# Altium schematic sheet [03] - External Connectors.SchDoc("[03] - External Connectors")
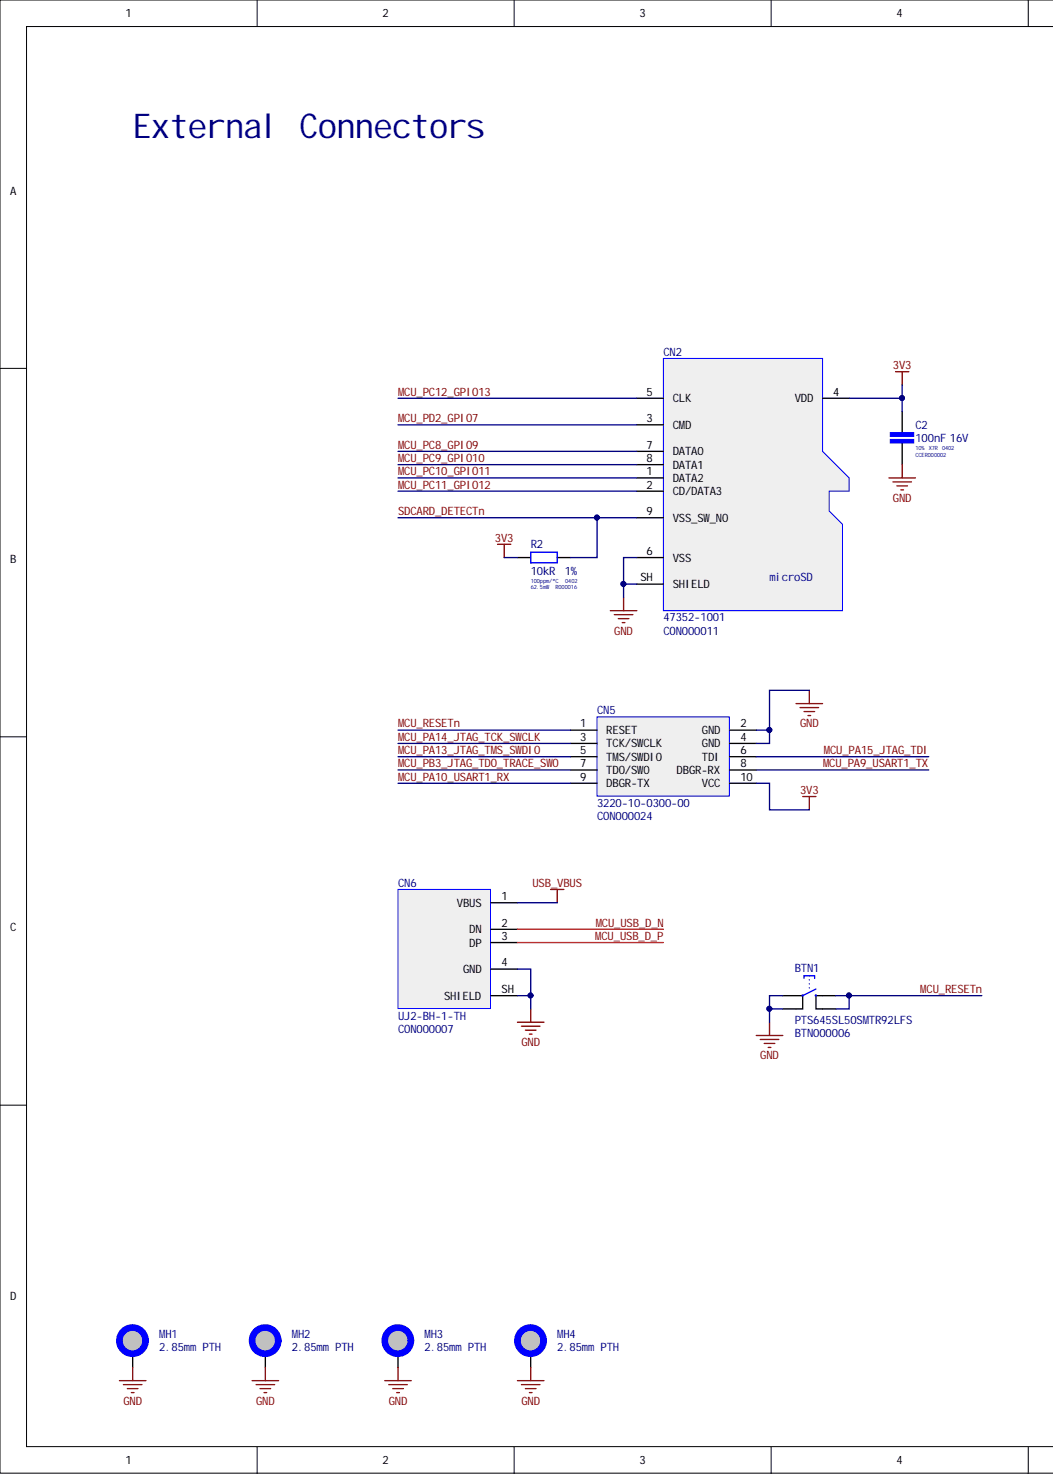
[diagram p0: [03] - External Connectors.SchDoc, left]
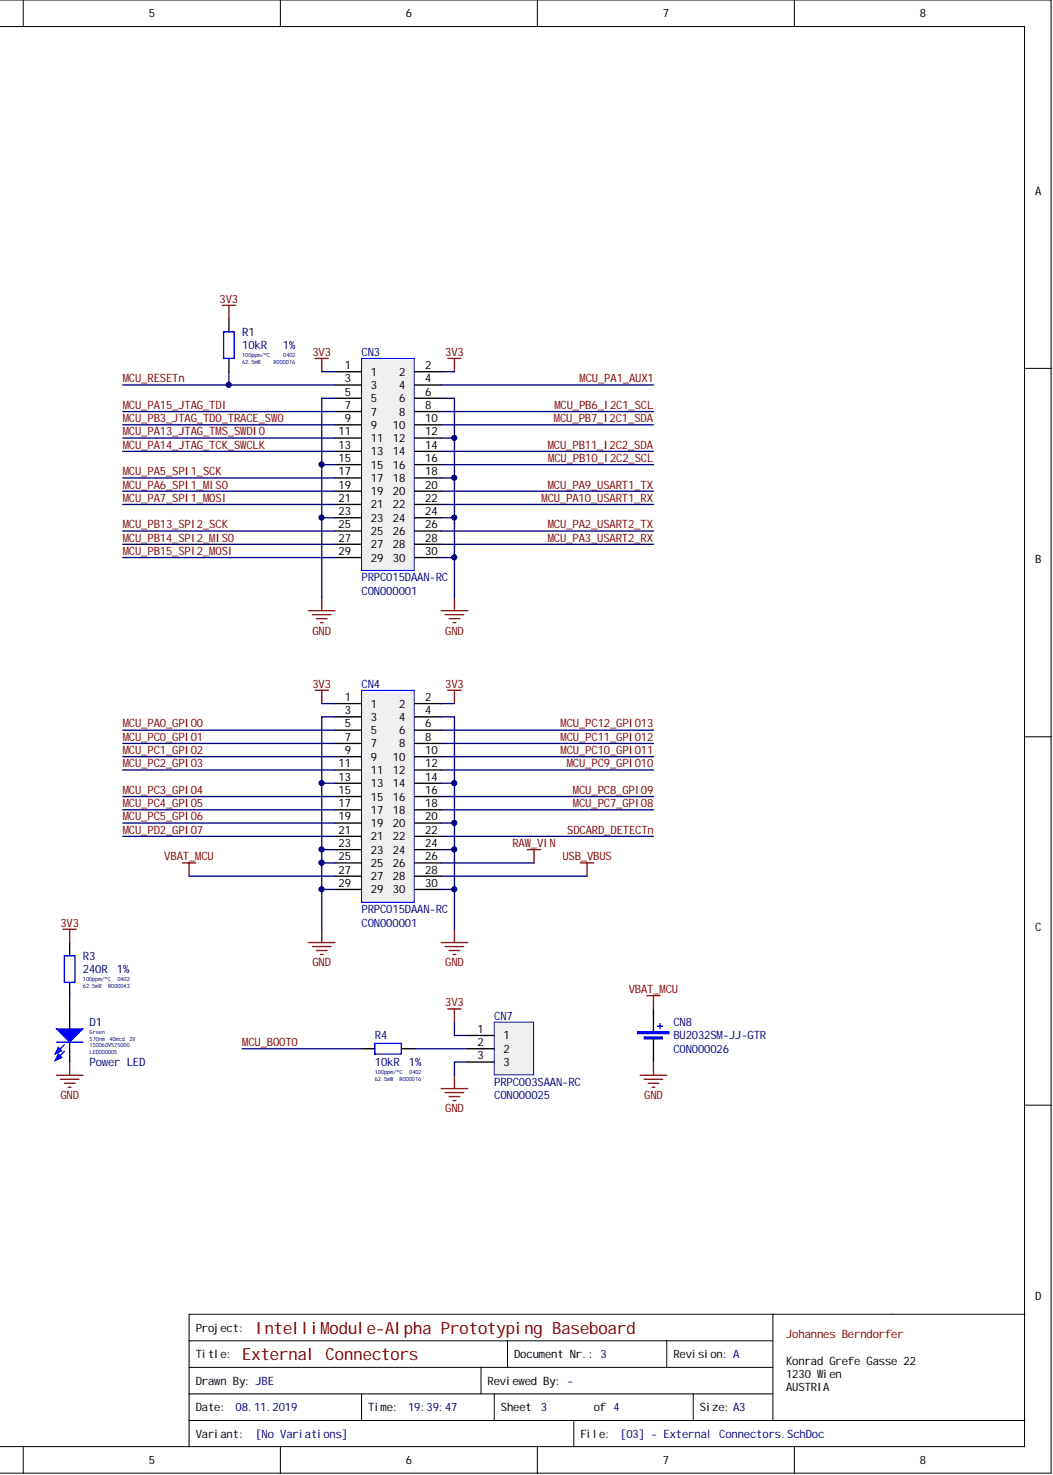
[diagram p1: [03] - External Connectors.SchDoc, right]

## Parts
BTN1  PTS645SL50SMTR92LFS  Internal Part Number: BTN000006  [BTN-SMD-PTS645-CK]
C2  100nF 16V  Tolerance: 10%  Internal Part Number: CCER000002  Capacitance: 100nF  [CAP-SMD-0402-0.55MM-IPC-MED]
CN2  47352-1001  [CON-SMD-MICROSD-PUSHPULL-9POS-0473521001-MOLEX]
CN3  PRPC015DAAN-RC  [CON-THT-PINHEADER-2.54MM-30POS-2X15]
CN4  PRPC015DAAN-RC  [CON-THT-PINHEADER-2.54MM-30POS-2X15]
CN5  3220-10-0300-00  [CON-SMD-PINHEADER-SHIELDED-1.27MM-10POS-2X5-3220-10-0300-00-CNC-TECH]
CN6  UJ2-BH-1-TH  [CON-THT-USB-B-4POS-UJ2-BH-1-TH-CUI]
CN7  PRPC003SAAN-RC  [CON-THT-PINHEADER-2.54MM-3POS-1X3]
CN8  BU2032SM-JJ-GTR  [CON-SMD-BU2032SM-JJ-GTR]
D1  150060VS75000  Wavelength: 570nm  Luminous Intensity: 40mcd  Internal Part Number: LED000005  Color: Green  [LED-SMD-0603]
MH1  2.85mm PTH  [MECH-HOLE-PTH-2.85MM-5.4MM]
MH2  2.85mm PTH  [MECH-HOLE-PTH-2.85MM-5.4MM]
MH3  2.85mm PTH  [MECH-HOLE-PTH-2.85MM-5.4MM]
MH4  2.85mm PTH  [MECH-HOLE-PTH-2.85MM-5.4MM]
R1  Temperature Coefficient: 100ppm/°C  Resistance: 10kR  Power Rating: 62.5mW  [RES-SMD-0402-0.4MM-IPC-MED]  (hidden comment: 10kR 1%)
R2  10kR 1%  Tolerance: 1%  Temperature Coefficient: 100ppm/°C  Resistance: 10kR  Power Rating: 62.5mW  Internal Part Number: R000016  Case Code (Imperial): 0402  [RES-SMD-0402-0.4MM-IPC-MED]
R3  240R 1%  Temperature Coefficient: 100ppm/°C  Resistance: 240R  Power Rating: 62.5mW  [RES-SMD-0402-0.4MM-IPC-MED]
R4  10kR 1%  Tolerance: 1%  Temperature Coefficient: 100ppm/°C  Resistance: 10kR  Power Rating: 62.5mW  Internal Part Number: R000016  Case Code (Imperial): 0402  [RES-SMD-0402-0.4MM-IPC-MED]

## Nets
3V3: C2.1 CN2.4(VDD) CN3.1 CN3.2 CN4.1 CN4.2 CN5.10(VCC) CN7.1 R1.1 R2.1 R3.1
GND: BTN1.1 BTN1.2 C2.2 CN2.6(VSS) CN2.SH(SHIELD) CN3.5 CN3.6 CN3.12 CN3.15 CN3.18 CN3.23 CN3.24 CN3.30 CN4.3 CN4.4 CN4.13 CN4.14 CN4.20 CN4.23 CN4.24 CN4.25 CN4.29 CN4.30 CN5.2(GND) CN5.4(GND) CN6.4(GND) CN6.SH(SHIELD) CN7.3 CN8.2 D1.1 MH1.1 MH2.1 MH3.1 MH4.1
RAW_VIN: CN4.26
USB_VBUS: CN4.28 CN6.1(VBUS)
VBAT_MCU: CN4.27 CN8.1
MCU_BOOT0: R4.1
MCU_PA0_GPIO0: CN4.5
MCU_PA1_AUX1: CN3.4
MCU_PA2_USART2_TX: CN3.26
MCU_PA3_USART2_RX: CN3.28
MCU_PA5_SPI1_SCK: CN3.17
MCU_PA6_SPI1_MISO: CN3.19
MCU_PA7_SPI1_MOSI: CN3.21
MCU_PA9_USART1_TX: CN3.20 CN5.8(DBGR-RX)
MCU_PA10_USART1_RX: CN3.22 CN5.9(DBGR-TX)
MCU_PA13_JTAG_TMS_SWDIO: CN3.11 CN5.5(TMS/SWDIO)
MCU_PA14_JTAG_TCK_SWCLK: CN3.13 CN5.3(TCK/SWCLK)
MCU_PA15_JTAG_TDI: CN3.7 CN5.6(TDI)
MCU_PB3_JTAG_TDO_TRACE_SWO: CN3.9 CN5.7(TDO/SWO)
MCU_PB6_I2C1_SCL: CN3.8
MCU_PB7_I2C1_SDA: CN3.10
MCU_PB10_I2C2_SCL: CN3.16
MCU_PB11_I2C2_SDA: CN3.14
MCU_PB13_SPI2_SCK: CN3.25
MCU_PB14_SPI2_MISO: CN3.27
MCU_PB15_SPI2_MOSI: CN3.29
MCU_PC0_GPIO1: CN4.7
MCU_PC1_GPIO2: CN4.9
MCU_PC2_GPIO3: CN4.11
MCU_PC3_GPIO4: CN4.15
MCU_PC4_GPIO5: CN4.17
MCU_PC5_GPIO6: CN4.19
MCU_PC7_GPIO8: CN4.18
MCU_PC8_GPIO9: CN2.7(DATA0) CN4.16
MCU_PC9_GPIO10: CN2.8(DATA1) CN4.12
MCU_PC10_GPIO11: CN2.1(DATA2) CN4.10
MCU_PC11_GPIO12: CN2.2(CD/DATA3) CN4.8
MCU_PC12_GPIO13: CN2.5(CLK) CN4.6
MCU_PD2_GPIO7: CN2.3(CMD) CN4.21
MCU_RESETn: BTN1.3 BTN1.4 CN3.3 CN5.1(RESET) R1.2
MCU_USB_D_N: CN6.2(DN)
MCU_USB_D_P: CN6.3(DP)
SDCARD_DETECTn: CN2.9(VSS_SW_NO) CN4.22 R2.2
NetCN7_2: CN7.2 R4.2
NetD1_2: D1.2 R3.2

## Library data of the parts
BTN1 = PTS645SL50SMTR92LFS: BTN TACT SPST-NO 12V 50mA SMD BLACK; Manufacturer: ITT C&K; Throw Configuration: SPST; Switch Function: Off-Mom; Operating Force: 1.27N; Mechanical Life: 100000Cycles; Illumination Type: Non-Illuminated; Actuator Type: Standard; Actuator Material: Nylon,Polyamide; Voltage Rating (DC): 12V; Termination: Gull Wing; Supplier Part Number 1: CKN9088CT-ND; Supplier 1: Digi-Key; Stock 1: 127172; RoHS: Yes; REACH SVHC: No SVHC; Pricing 1: 1 = 0,31000, 10 = 0,30000, 25 = 0,29000, 50 = 0,27960, 100 = 0,26410, 250 = 0,24860, 500 = 0,23822 (USD); Packaging: Tape and Reel; Package Quantity: 1000; Orientation: Straight; Mount: Surface Mount; Min Operating Temperature: -20°C; Max Voltage Rating (DC): 12V; Max Operating Temperature: 60°C; Max Current Rating: 50mA; Manufacturer Part Number: PTS645SL50SMTR92LFS; Library Name: Altium_Lib_Standard.IntLib; Length: 6mm; Lead Free: Lead Free; Depth: 9mm; Current Rating: 50mA; Contact Plating: Silver; Contact Material: Bronze; ComponentLink6URL: https://datasheet.ciiva.com/46429/1839862-46429338.pdf?src-supplier=Element14; ComponentLink6Description: https://datasheet.ciiva.com/46429/1839862-46429338.pdf?src-supplier=Element14; ComponentLink5URL: https://datasheet.ciiva.com/46429/1839862-46429337.pdf?src-supplier=Element14; ComponentLink5Description: https://datasheet.ciiva.com/46429/1839862-46429337.pdf?src-supplier=Element14; ComponentLink4URL: https://datasheet.ciiva.com/14920/pts645-14920744.pdf?src-supplier=Digi-Key; ComponentLink4Description: https://datasheet.ciiva.com/14920/pts645-14920744.pdf?src-supplier=Digi-Key; ComponentLink3URL: https://datasheet.ciiva.com/14920/pts645-14920743.pdf?src-supplier=Digi-Key; ComponentLink3Description: https://datasheet.ciiva.com/14920/pts645-14920743.pdf?src-supplier=Digi-Key; ComponentLink2URL: https://datasheet.ciiva.com/14920/pts645-14920742.pdf?src-supplier=Digi-Key; ComponentLink2Description: https://datasheet.ciiva.com/14920/pts645-14920742.pdf?src-supplier=Digi-Key; ComponentLink1URL: https://datasheet.ciiva.com/26927/getdatasheetpartid-760859-26927133.pdf?src-supplier=Verical; ComponentLink1Description: https://datasheet.ciiva.com/26927/getdatasheetpartid-760859-26927133.pdf?src-supplier=Verical; Component Kind: Standard; Color: Black
C2 = CL05B104KO5NNNC: CAP CER 100N 16V X7R 10% SMD 0402 125C SAMSUNG; Material: Ceramic; Width: 0.02inch; Voltage Rating (DC): 16V; Voltage Rating: 16V; Voltage: 16V; Thickness: 0.022inch; Supplier Part Number 1: 1276-1001-6-ND; Supplier 1: Digi-Key; Stock 1: 53930414; RoHS: Yes; Radiation Hardening: No; Pricing 1: 1=0,10000, 10=0,02400, 100=0,01070, 500=0,00766, 1000=0,00602, 2500=0,00548, 5000=0,00504 (USD); Packaging: Tape and Reel; Package Quantity: 1; Mount: Surface Mount; Min Operating Temperature: -55°C; Max Operating Temperature: 125°C; Manufacturer Part Number 1: CL05B104KO5NNNC; Manufacturer 1: Samsung; Library Name: Altium_Lib_Standard.IntLib; Length: 1mm; Lead Free: Lead Free; Height: 0.5mm; Dielectric: X7R; Depth: 0.5mm; Contact Plating: Tin; ComponentLink4URL: https://datasheet.ciiva.com/26309/mlcc-catalogdownload2013-eng-26309003.pdf?src-supplier=Distrelec; ComponentLink4Description: https://datasheet.ciiva.com/26309/mlcc-catalogdownload2013-eng-26309003.pdf?src-supplier=Distrelec; ComponentLink3URL: https://datasheet.ciiva.com/16208/cl05b104kq5nnnc-16208343.pdf?src-supplier=Future+Electronics; ComponentLink3Description: https://datasheet.ciiva.com/16208/cl05b104kq5nnnc-16208343.pdf?src-supplier=Future+Electronics; ComponentLink2URL: https://datasheet.ciiva.com/29198/458718-da-01-en-vs-kondensator-0402-29198423.pdf?src-supplier=Conrad; ComponentLink2Description: https://datasheet.ciiva.com/29198/458718-da-01-en-vs-kondensator-0402-29198423.pdf?src-supplier=Conrad; ComponentLink1URL: https://datasheet.ciiva.com/26920/getdatasheetpartid-359683-26920169.pdf?src-supplier=Verical; ComponentLink1Description: https://datasheet.ciiva.com/26920/getdatasheetpartid-359683-26920169.pdf?src-supplier=Verical; Component Kind: Standard; Case Code (Metric): 1005; Case Code (Imperial): 0402; Case/Package: 0402
CN2 = MOLEX 47352-1001: CON MICROSD SMD PUSH PUSH TYPE VSS SW; Manufacturer 1: Molex; Internal Part Number: CON000011; Pitch: 1.1mm; Number of Contacts: 8; Max Voltage Rating (DC): 100V; Gender: Male; Connector Type: Connector; Termination: Solder; Supplier Part Number 1: WM3290CT-ND; Supplier 1: Digi-Key; Stock 1: 16604; RoHS: Yes; Radiation Hardening: No; Pricing 1: 1=3,94000, 10=3,78500, 25=3,47000, 50=3,31240, 100=3,15460, 250=2,76024 (USD); Packaging: Tape and Reel; Package Quantity: 1; Orientation: Right Angle; Number of Rows: 1; Mount: Surface Mount; Min Operating Temperature: -20°C; Max Operating Temperature: 85°C; Manufacturer Part Number 1: 47352-1001; Library Name: Altium_Lib_Standard.IntLib; Length: 14mm; Housing Material: Polymer; Depth: 15.2mm; Contact Plating: Gold; Contact Material: Copper Alloy; ComponentLink5URL: https://datasheet.ciiva.com/683/473521001-sd-683627.pdf?src-supplier=Digi-Key; ComponentLink5Description: https://datasheet.ciiva.com/683/473521001-sd-683627.pdf?src-supplier=Digi-Key; ComponentLink4URL: https://datasheet.ciiva.com/30105/0473521001-memory-card-socket-30105258.pdf?src-supplier=Onlinecomponents.com; ComponentLink4Description: https://datasheet.ciiva.com/30105/0473521001-memory-card-socket-30105258.pdf?src-supplier=Onlinecomponents.com; ComponentLink3URL: https://datasheet.ciiva.com/683/473521001-sd-683625.pdf?src-supplier=Digi-Key; ComponentLink3Description: https://datasheet.ciiva.com/683/473521001-sd-683625.pdf?src-supplier=Digi-Key; ComponentLink2URL: https://datasheet.ciiva.com/16547/0473521001-memory-card-socket-16547169.pdf?src-supplier=Avnet+Europe; ComponentLink2Description: https://datasheet.ciiva.com/16547/0473521001-memory-card-socket-16547169.pdf?src-supplier=Avnet+Europe; ComponentLink1URL: https://datasheet.ciiva.com/11755/0900766b80f75287-11755041.pdf?src-supplier=RSComponents; ComponentLink1Description: https://datasheet.ciiva.com/11755/0900766b80f75287-11755041.pdf?src-supplier=RSComponents; Component Kind: Standard
CN3 CN4 = PRPC015DAAN-RC: CON PINHDR 2MM54 2X15; Manufacturer: Sullins; Internal Part Number: CON000001; Row Spacing: 0.1inch; Number of Positions: 30; Connector Type: Male Pin; Termination: Solder; Supplier Part Number 1: S2011EC-15-ND; Supplier 1: Digi-Key; Stock 1: 2778; RoHS: Yes; Pricing 1: 1 = 0,74000, 10 = 0,69700, 25 = 0,62680, 50 = 0,55720, 100 = 0,53410, 250 = 0,48764, 500 = 0,46440 (USD); Pitch: 0.1inch; Packaging: Bulk; Package Quantity: 1; Number of Rows: 2; Mount: Through Hole; Manufacturer Part Number: PRPC015DAAN-RC; Library Name: Altium_Lib_Standard.IntLib; Contact Plating: Gold; Contact Mating Length: 0.23inch; ComponentLink1URL: https://datasheet.ciiva.com/741/xrxczzzdxxn-rc-11636-b-741270.pdf?src-supplier=Digi-Key; ComponentLink1Description: https://datasheet.ciiva.com/741/xrxczzzdxxn-rc-11636-b-741270.pdf?src-supplier=Digi-Key; Component Kind: Standard; Color: Black
CN5 = 3220-10-0300-00: CON PINHDR SMD 1.27MM SHIELDED KEYED CNC TECH 10POS; Internal Part Number: CON000024; Row Spacing: 0.05inch; Number of Positions: 10; Connector Type: Male Pin; Termination: Solder; Supplier Part Number 1: 1175-1629-ND; Supplier 1: Digi-Key; Stock 1: 107713; RoHS: Yes; Pricing 1: 1=0,74000, 10=0,69000, 100=0,52900, 500=0,46000, 3200=0,34500, 6400=0,33350, 12800=0,30590 (USD); Pitch: 0.05inch; Packaging: Tray; Package Quantity: 3200; Number of Rows: 2; Mount: Surface Mount; Manufacturer Part Number 1: 3220-10-0300-00; Manufacturer 1: CNC Tech; Library Name: Altium_Lib_Standard.IntLib; Contact Plating: Gold; ComponentLink1URL: https://datasheet.ciiva.com/18655/3220-xx-0300-00-18655365.pdf?src-supplier=Digi-Key; ComponentLink1Description: https://datasheet.ciiva.com/18655/3220-xx-0300-00-18655365.pdf?src-supplier=Digi-Key; Component Kind: Standard
CN6 = UJ2-BH-1-TH: CON USB 2.0 B THROUGH HOLE WHTE INSULATOR; Manufacturer: CUI; Internal Part Number: CON000007; Number of Contacts: 4; Gender: Receptacle; Connector Type: Jack; Supplier Part Number 1: 102-3999-ND; Supplier 1: Digi-Key; Stock 1: 0; RoHS: Yes; Packaging: Tray; Orientation: Horizontal; Number of Pins: 4; Mount: Through Hole,Horizontal; Manufacturer Part Number: UJ2-BH-1-TH; Library Name: Altium_Lib_Standard.IntLib; Lead Free: Lead Free; Features: Shielded; ComponentLink1URL: https://www.cui.com/product/resource/digikeypdf/uj2-bh-th.pdf?src-supplier=Digi-Key; ComponentLink1Description: https://www.cui.com/product/resource/digikeypdf/uj2-bh-th.pdf?src-supplier=Digi-Key; Component Kind: Standard
CN7 = PRPC003SAAN-RC: CON PINHDR 2.54MM 1x03; Manufacturer: Sullins; Internal Part Number: CON000025; Pitch: 0.1inch; Number of Positions: 3; Connector Type: Male Pin; Termination: Solder; Supplier Part Number 1: S1011EC-03-ND; Supplier 1: Digi-Key; Stock 1: 16923; RoHS: Yes; Pricing 1: 1 = 0,12000, 10 = 0,10900, 25 = 0,08120, 50 = 0,06960, 100 = 0,06730, 250 = 0,05804, 500 = 0,05572 (USD); Packaging: Bulk; Package Quantity: 1; Number of Rows: 1; Mount: Through Hole; Manufacturer Part Number: PRPC003SAAN-RC; Library Name: Altium_Lib_Standard.IntLib; Contact Plating: Gold; Contact Mating Length: 0.23inch; ComponentLink1URL: https://datasheet.ciiva.com/741/xrxczzzsxxn-rc-st-11635-b-741242.pdf?src-supplier=Digi-Key; ComponentLink1Description: https://datasheet.ciiva.com/741/xrxczzzsxxn-rc-st-11635-b-741242.pdf?src-supplier=Digi-Key; Component Kind: Standard; Color: Black
CN8 = BU2032SM-JJ-GTR: CON BATTERY HOLDER 2032 COINCELL SMD; Manufacturer: Memory Protection Devices; Internal Part Number: CON000026; Number of Cells: 1; Termination: SMD/SMT; Supplier Part Number 1: BU2032SM-JJ-GCT-ND; Supplier 1: Digi-Key; Stock 1: 93681; RoHS: Yes; Pricing 1: 1 = 0,99000, 10 = 0,83600, 100 = 0,69070 (USD); Package Quantity: 400; Mount: Surface Mount,PCB; Min Operating Temperature: -40°C; Max Operating Temperature: 280°C; Manufacturer Part Number: BU2032SM-JJ-GTR; Library Name: Altium_Lib_Standard.IntLib; ComponentLink3URL: https://datasheet.ciiva.com/3/bu2032sm-jj-gtr-datasheet-3837.pdf?src-supplier=Digi-Key; ComponentLink3Description: https://datasheet.ciiva.com/3/bu2032sm-jj-gtr-datasheet-3837.pdf?src-supplier=Digi-Key; ComponentLink2URL: https://datasheet.ciiva.com/3/bu2032sm-jj-gtr-datasheet-3836.pdf?src-supplier=Digi-Key; ComponentLink2Description: https://datasheet.ciiva.com/3/bu2032sm-jj-gtr-datasheet-3836.pdf?src-supplier=Digi-Key; ComponentLink1URL: https://datasheet.ciiva.com/3/bu2032sm-jj-gtr-datasheet-3835.pdf?src-supplier=Digi-Key; ComponentLink1Description: https://datasheet.ciiva.com/3/bu2032sm-jj-gtr-datasheet-3835.pdf?src-supplier=Digi-Key; Component Kind: Standard
D1 = 150060VS75000: LED GREEN570NM SMD 0603 2V 20mA 40mcd; Manufacturer: Wurth Electronics; Viewing Angle: 140°; Lens Style: Clear,Rectangular; Forward Current: 30mA; Width: 0.8mm; Test Current: 20mA; Supplier Part Number 1: 732-4980-1-ND; Supplier 1: Digi-Key; Stock 1: 94015; RoHS: Yes; REACH SVHC: Unknown; Pricing 1: 1 = 0,14000, 50 = 0,12900, 100 = 0,11000, 500 = 0,09500 (USD); Packaging: Tape and Reel; Package Quantity: 1; Number of Pins: 2; Mount: Surface Mount; Min Operating Temperature: -40°C; Max Operating Temperature: 85°C; Manufacturer Part Number: 150060VS75000; Library Name: Altium_Lib_Standard.IntLib; Length: 1.6mm; Height: 0.7mm; Forward Voltage: 2V; Dominant Wavelength: 570nm; ComponentLink6URL: https://datasheet.ciiva.com/48430/150060vs75000-eng-tds-48430043.pdf?src-supplier=Distrelec; ComponentLink6Description: https://datasheet.ciiva.com/48430/150060vs75000-eng-tds-48430043.pdf?src-supplier=Distrelec; ComponentLink5URL: https://datasheet.ciiva.com/29562/150060vs75000-29562921.pdf?src-supplier=Digi-Key; ComponentLink5Description: https://datasheet.ciiva.com/29562/150060vs75000-29562921.pdf?src-supplier=Digi-Key; ComponentLink4URL: https://datasheet.ciiva.com/29562/150060vs75000-29562920.pdf?src-supplier=Digi-Key; ComponentLink4Description: https://datasheet.ciiva.com/29562/150060vs75000-29562920.pdf?src-supplier=Digi-Key; ComponentLink3URL: https://datasheet.ciiva.com/29562/150060vs75000-29562919.pdf?src-supplier=Digi-Key; ComponentLink3Description: https://datasheet.ciiva.com/29562/150060vs75000-29562919.pdf?src-supplier=Digi-Key; ComponentLink2URL: https://datasheet.ciiva.com/29279/759431-da-01-en-chipled-0603-w-kl-h-gruen-150060vs7500-29279199.pdf?src-supplier=Conrad; ComponentLink2Description: https://datasheet.ciiva.com/29279/759431-da-01-en-chipled-0603-w-kl-h-gruen-150060vs7500-29279199.pdf?src-supplier=Conrad; ComponentLink1URL: https://datasheet.ciiva.com/23843/150060vs75000-23843249.pdf?src-supplier=Newark; ComponentLink1Description: https://datasheet.ciiva.com/23843/150060vs75000-23843249.pdf?src-supplier=Newark; Component Kind: Standard; Case Code (Metric): 1608; Case Code (Imperial): 0603; Case/Package: 0603
MH1-MH4 = MECH-HOLE-PTH-2.85MM-5.4MM: 2.85mm Diameter 5.4mm Pad Plated Through Hole; Library Name: Altium_Lib_Standard.IntLib; Component Kind: Standard
R1 = RC0402FR-0710KL: RES 10K 1% 1/16W SMD 0402 YAEGO; Manufacturer: Yageo; Internal Part Number: R000016; Working Voltage: 50V; Width: 0.5mm; Voltage Rating (DC): 50V; Voltage Rating: 50V; Tolerance: 1%; Termination: SMD/SMT; Supplier Part Number 1: 311-10.0KLRCT-ND; Supplier 1: Digi-Key; Stock 1: 86154015; RoHS: Yes; REACH SVHC: No SVHC; Pricing 1: 1 = 0,10000, 10 = 0,01600, 100 = 0,00670, 1000 = 0,00301, 2500 = 0,00261, 5000 = 0,00215 (USD); Packaging: Tape and Reel; Package Quantity: 10000; Number of Terminations: 2; Number of Pins: 2; Min Operating Temperature: -55°C; Military Standard: Not; Max Operating Temperature: 155°C; Manufacturer Part Number: RC0402FR-0710KL; Library Name: Altium_Lib_Standard.IntLib; Length: 1mm; Lead Pitch: 0.2mm; Lead Free: Lead Free; Height: 0.32mm; Depth: 0.5mm; Composition: Thick Film; ComponentLink13URL: https://datasheet.ciiva.com/17070/pyu-rc0402-51-rohs-l-6-r-17070335.pdf?src-supplier=Future+Electronics; ComponentLink13Description: https://datasheet.ciiva.com/17070/pyu-rc0402-51-rohs-l-6-r-17070335.pdf?src-supplier=Future+Electronics; ComponentLink12URL: https://datasheet.ciiva.com/7849/1018594-7849967.pdf?src-supplier=Element14; ComponentLink12Description: https://datasheet.ciiva.com/7849/1018594-7849967.pdf?src-supplier=Element14; ComponentLink11URL: https://datasheet.ciiva.com/7849/1018594-7849966.pdf?src-supplier=Element14; ComponentLink11Description: https://datasheet.ciiva.com/7849/1018594-7849966.pdf?src-supplier=Element14; ComponentLink10URL: https://datasheet.ciiva.com/24070/pyu-rc0402-51-rohs-l-6-r-8721-24070077.pdf?src-supplier=Mouser; ComponentLink10Description: https://datasheet.ciiva.com/24070/pyu-rc0402-51-rohs-l-6-r-8721-24070077.pdf?src-supplier=Mouser; ComponentLink9URL: https://datasheet.ciiva.com/15189/getdatasheetpartid-329253-15189431.pdf?src-supplier=Verical; ComponentLink9Description: https://datasheet.ciiva.com/15189/getdatasheetpartid-329253-15189431.pdf?src-supplier=Verical; ComponentLink8URL: https://datasheet.ciiva.com/11819/0900766b80c66297-11819487.pdf?src-supplier=RSComponents; ComponentLink8Description: https://datasheet.ciiva.com/11819/0900766b80c66297-11819487.pdf?src-supplier=RSComponents; ComponentLink7URL: https://datasheet.ciiva.com/7983/1018594-7983486.pdf?src-supplier=Element14; ComponentLink7Description: https://datasheet.ciiva.com/7983/1018594-7983486.pdf?src-supplier=Element14; ComponentLink6URL: https://datasheet.ciiva.com/12667/pyu-rc0402-51-rohs-l-6-r-12667067.pdf?src-supplier=Mouser; ComponentLink6Description: https://datasheet.ciiva.com/12667/pyu-rc0402-51-rohs-l-6-r-12667067.pdf?src-supplier=Mouser; ComponentLink5URL: https://datasheet.ciiva.com/22747/1018594-22747512.pdf?src-supplier=Element14; ComponentLink5Description: https://datasheet.ciiva.com/22747/1018594-22747512.pdf?src-supplier=Element14; ComponentLink4URL: https://datasheet.ciiva.com/7849/1784430-7849815.pdf?src-supplier=Element14; ComponentLink4Description: https://datasheet.ciiva.com/7849/1784430-7849815.pdf?src-supplier=Element14; ComponentLink3URL: https://datasheet.ciiva.com/7849/1686128-7849814.pdf?src-supplier=Element14; ComponentLink3Description: https://datasheet.ciiva.com/7849/1686128-7849814.pdf?src-supplier=Element14; ComponentLink2URL: https://datasheet.ciiva.com/7849/1784430-7849813.pdf?src-supplier=Element14; ComponentLink2Description: https://datasheet.ciiva.com/7849/1784430-7849813.pdf?src-supplier=Element14; ComponentLink1URL: https://datasheet.ciiva.com/7660/38568-7660787.pdf?src-supplier=Element14; ComponentLink1Description: https://datasheet.ciiva.com/7660/38568-7660787.pdf?src-supplier=Element14; Component Kind: Standard; Case Code (Metric): 1005; Case Code (Imperial): 0402; Case/Package: 0402
R2 R4 = RC0402FR-0710KL: RES 10K 1% 1/16W SMD 0402 YAEGO; Manufacturer: Yageo; Working Voltage: 50V; Width: 0.5mm; Voltage Rating (DC): 50V; Voltage Rating: 50V; Termination: SMD/SMT; Supplier Part Number 1: 311-10.0KLRCT-ND; Supplier 1: Digi-Key; Stock 1: 86154015; RoHS: Yes; REACH SVHC: No SVHC; Pricing 1: 1 = 0,10000, 10 = 0,01600, 100 = 0,00670, 1000 = 0,00301, 2500 = 0,00261, 5000 = 0,00215 (USD); Packaging: Tape and Reel; Package Quantity: 10000; Number of Terminations: 2; Number of Pins: 2; Min Operating Temperature: -55°C; Military Standard: Not; Max Operating Temperature: 155°C; Manufacturer Part Number: RC0402FR-0710KL; Library Name: Altium_Lib_Standard.IntLib; Length: 1mm; Lead Pitch: 0.2mm; Lead Free: Lead Free; Height: 0.32mm; Depth: 0.5mm; Composition: Thick Film; ComponentLink13URL: https://datasheet.ciiva.com/17070/pyu-rc0402-51-rohs-l-6-r-17070335.pdf?src-supplier=Future+Electronics; ComponentLink13Description: https://datasheet.ciiva.com/17070/pyu-rc0402-51-rohs-l-6-r-17070335.pdf?src-supplier=Future+Electronics; ComponentLink12URL: https://datasheet.ciiva.com/7849/1018594-7849967.pdf?src-supplier=Element14; ComponentLink12Description: https://datasheet.ciiva.com/7849/1018594-7849967.pdf?src-supplier=Element14; ComponentLink11URL: https://datasheet.ciiva.com/7849/1018594-7849966.pdf?src-supplier=Element14; ComponentLink11Description: https://datasheet.ciiva.com/7849/1018594-7849966.pdf?src-supplier=Element14; ComponentLink10URL: https://datasheet.ciiva.com/24070/pyu-rc0402-51-rohs-l-6-r-8721-24070077.pdf?src-supplier=Mouser; ComponentLink10Description: https://datasheet.ciiva.com/24070/pyu-rc0402-51-rohs-l-6-r-8721-24070077.pdf?src-supplier=Mouser; ComponentLink9URL: https://datasheet.ciiva.com/15189/getdatasheetpartid-329253-15189431.pdf?src-supplier=Verical; ComponentLink9Description: https://datasheet.ciiva.com/15189/getdatasheetpartid-329253-15189431.pdf?src-supplier=Verical; ComponentLink8URL: https://datasheet.ciiva.com/11819/0900766b80c66297-11819487.pdf?src-supplier=RSComponents; ComponentLink8Description: https://datasheet.ciiva.com/11819/0900766b80c66297-11819487.pdf?src-supplier=RSComponents; ComponentLink7URL: https://datasheet.ciiva.com/7983/1018594-7983486.pdf?src-supplier=Element14; ComponentLink7Description: https://datasheet.ciiva.com/7983/1018594-7983486.pdf?src-supplier=Element14; ComponentLink6URL: https://datasheet.ciiva.com/12667/pyu-rc0402-51-rohs-l-6-r-12667067.pdf?src-supplier=Mouser; ComponentLink6Description: https://datasheet.ciiva.com/12667/pyu-rc0402-51-rohs-l-6-r-12667067.pdf?src-supplier=Mouser; ComponentLink5URL: https://datasheet.ciiva.com/22747/1018594-22747512.pdf?src-supplier=Element14; ComponentLink5Description: https://datasheet.ciiva.com/22747/1018594-22747512.pdf?src-supplier=Element14; ComponentLink4URL: https://datasheet.ciiva.com/7849/1784430-7849815.pdf?src-supplier=Element14; ComponentLink4Description: https://datasheet.ciiva.com/7849/1784430-7849815.pdf?src-supplier=Element14; ComponentLink3URL: https://datasheet.ciiva.com/7849/1686128-7849814.pdf?src-supplier=Element14; ComponentLink3Description: https://datasheet.ciiva.com/7849/1686128-7849814.pdf?src-supplier=Element14; ComponentLink2URL: https://datasheet.ciiva.com/7849/1784430-7849813.pdf?src-supplier=Element14; ComponentLink2Description: https://datasheet.ciiva.com/7849/1784430-7849813.pdf?src-supplier=Element14; ComponentLink1URL: https://datasheet.ciiva.com/7660/38568-7660787.pdf?src-supplier=Element14; ComponentLink1Description: https://datasheet.ciiva.com/7660/38568-7660787.pdf?src-supplier=Element14; Component Kind: Standard; Case Code (Metric): 1005; Case/Package: 0402
R3 = RC0402FR-07240RL: RES 240R 1% 1/16W SMD 0402 YAGEO; Manufacturer: Yageo Phycomp; Internal Part Number: R000043; Voltage Rating (DC): 50V; Tolerance: 1%; Supplier Part Number 1: 311-240LRCT-ND; Supplier 1: Digi-Key; Stock 1: 5684518; RoHS: Yes; REACH SVHC: No SVHC; Pricing 1: 1 = 0,10000, 10 = 0,01600, 100 = 0,00670, 1000 = 0,00301, 2500 = 0,00261, 5000 = 0,00215 (USD); Packaging: Cut Tape; Number of Pins: 2; Mount: Surface Mount; Min Operating Temperature: -55°C; Max Operating Temperature: 155°C; Manufacturer Part Number: RC0402FR-07240RL; Library Name: Altium_Lib_Standard.IntLib; Length: 1mm; Lead Free: Lead Free; Height: 0.32mm; Depth: 0.5mm; ComponentLink5URL: http://www.farnell.com/datasheets/2167052.pdf?src-supplier=Element14; ComponentLink5Description: http://www.farnell.com/datasheets/2167052.pdf?src-supplier=Element14; ComponentLink4URL: http://www.yageo.com/documents/recent/PYu-RC_Group_51_RoHS_L_10.pdf?src-supplier=Digi-Key; ComponentLink4Description: http://www.yageo.com/documents/recent/PYu-RC_Group_51_RoHS_L_10.pdf?src-supplier=Digi-Key; ComponentLink3URL: http://www.yageo.com/documents/recent/PYu-RC_Group_51_RoHS_L_9.pdf?src-supplier=Digi-Key; ComponentLink3Description: http://www.yageo.com/documents/recent/PYu-RC_Group_51_RoHS_L_9.pdf?src-supplier=Digi-Key; ComponentLink2URL: http://www.farnell.com/datasheets/2167052.pdf?src-supplier=Farnell; ComponentLink2Description: http://www.farnell.com/datasheets/2167052.pdf?src-supplier=Farnell; ComponentLink1URL: http://www.mouser.com/ds/2/447/PYu-RC0402_51_RoHS_L_6_r-8721.pdf?src-supplier=Mouser; ComponentLink1Description: http://www.mouser.com/ds/2/447/PYu-RC0402_51_RoHS_L_6_r-8721.pdf?src-supplier=Mouser; Component Kind: Standard; Case/Package: 0402
